# Altium schematic sheet karmanDB_amp.SchDoc
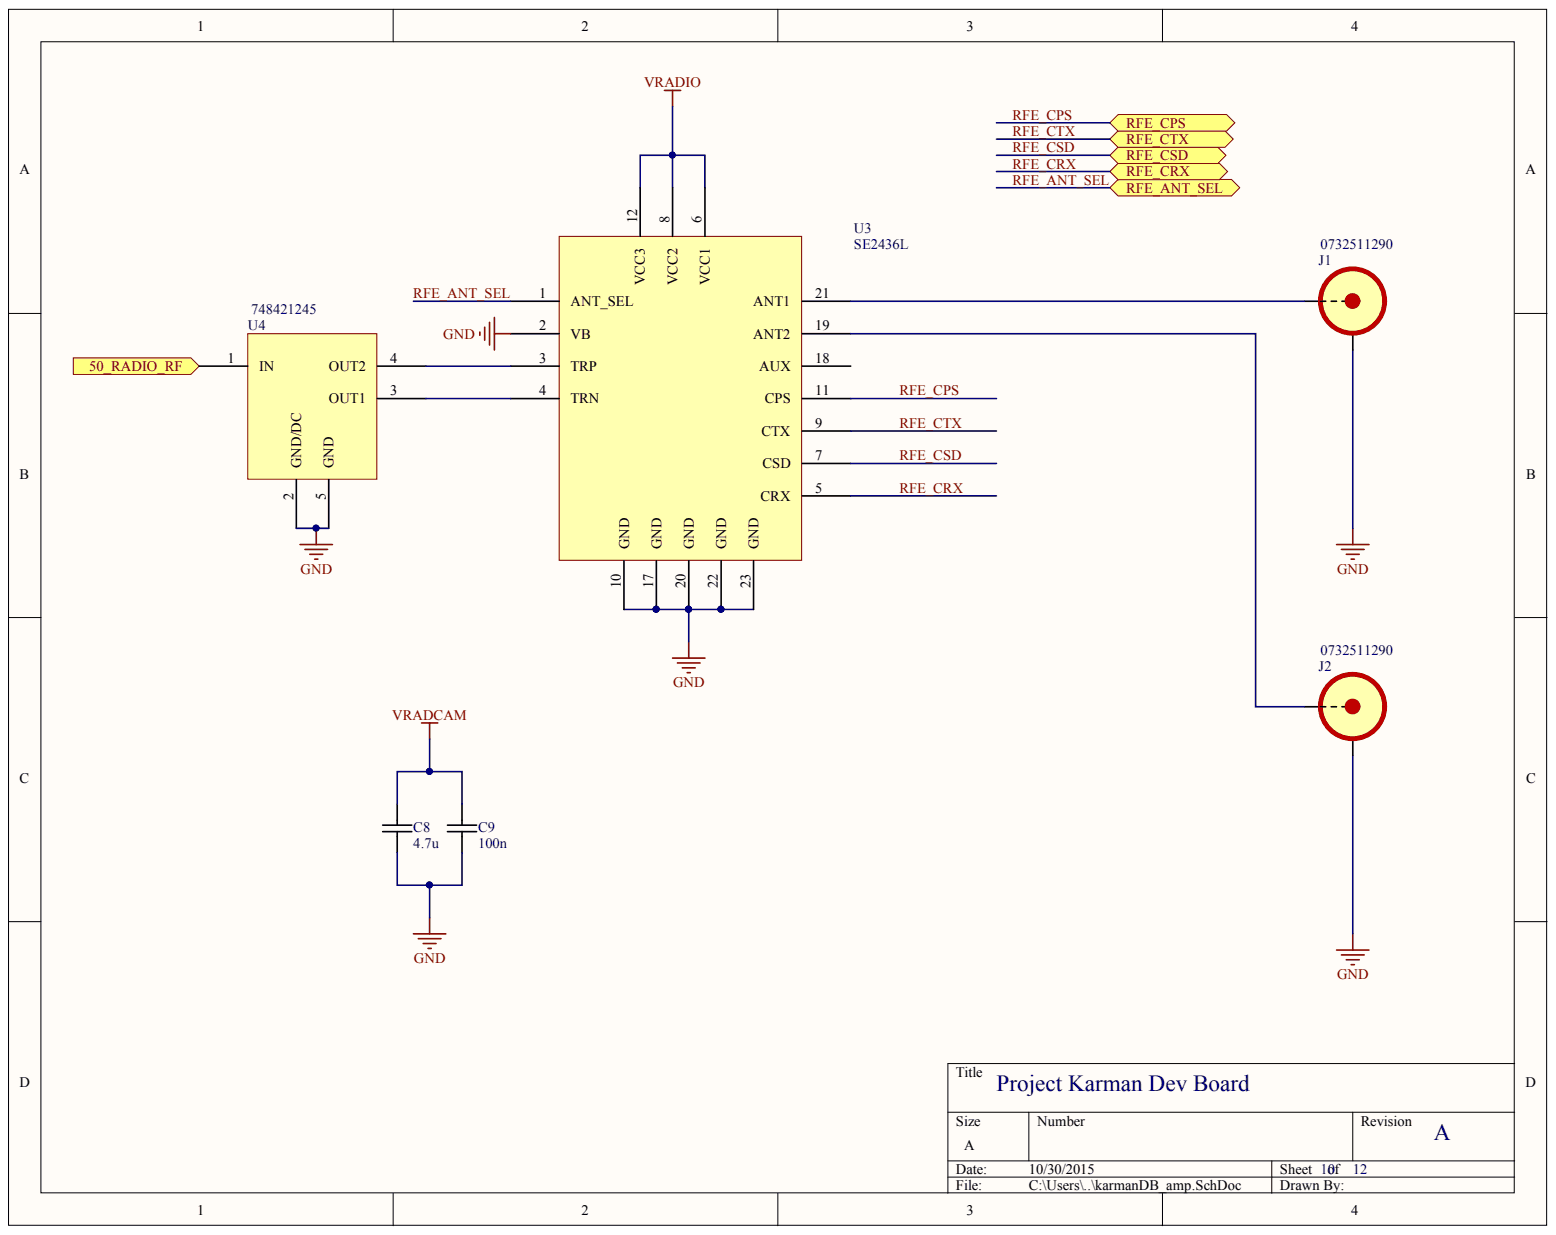
[diagram p0: karmanDB_amp.SchDoc]

## Parts
C8  4.7u  [MURATA_GRM_0603]
C9  100n  [MURATA_GRM_0603]
J1  0732511290
J2  0732511290
U3  SE2436L
U4  748421245

## Ports
50_RADIO_RF, RFE_ANT_SEL, RFE_CPS, RFE_CRX, RFE_CSD, RFE_CTX

## Nets
GND: C8.2 C9.2 J1.SHIELD J2.SHIELD U3.2(VB) U3.10(GND) U3.17(GND) U3.20(GND) U3.22(GND) U3.23(GND) U4.2(GND/DC) U4.5(GND)
VRADCAM: C8.1 C9.1
VRADIO: U3.6(VCC1) U3.8(VCC2) U3.12(VCC3)
50_RADIO_RF: U4.1(IN) ; port 50_RADIO_RF
RFE_ANT_SEL: U3.1(ANT_SEL) ; port RFE_ANT_SEL
RFE_CPS: U3.11(CPS) ; port RFE_CPS
RFE_CRX: U3.5(CRX) ; port RFE_CRX
RFE_CSD: U3.7(CSD) ; port RFE_CSD
RFE_CTX: U3.9(CTX) ; port RFE_CTX
NetJ1_PIN: J1.PIN U3.21(ANT1)
NetJ2_PIN: J2.PIN U3.19(ANT2)
NetU3_3: U3.3(TRP) U4.4(OUT2)
NetU3_4: U3.4(TRN) U4.3(OUT1)

Not connected: U3 18(AUX)

## Library data of the parts
C8 = CAP_MURATA_GRM188R61C475MAAJD: CAP CER 4.7UF 16V 20% X5R 0603; Manufacturer: Murata Electronics North America; Capacitance: 4.7µF; Applications: General Purpose; Category: Capacitors; Component Kind: Standard; ComponentLink1Description: http://www.murata.com/~/media/webrenewal/support/library/catalog/products/capacitor/mlcc/c02e.ashx; ComponentLink1URL: http://www.murata.com/~/media/webrenewal/support/library/catalog/products/capacitor/mlcc/c02e.ashx; Features: -; Height - Seated (Max): -; Lead Spacing: -; Lead Style: -; Library Name: project_symbols.SchLib; Manufacturer Part Number: GRM188R61C475MAAJD; Mounting Type: Surface Mount, MLCC; Operating Temperature: -55°C ~ 85°C; Package / Case: 0603 (1608 Metric); Packaging: Cut Tape (CT); Pricing: 1=0.3, 10=0.251, 25=0.1728, 50=0.1414, 100=0.1256, 250=0.0942, 500=0.08322, 1000=0.07379 (USD); Ratings: -; RoHS: RoHS Compliant; Size / Dimension: 0.063" L x 0.031" W (1.60mm x 0.80mm); Stock 1: 3465; Supplier 1: Digi-Key; Supplier Part Number 1: 490-10482-1-ND; Temperature Coefficient: X5R; Thickness (Max): 0.037" (0.95mm); Tolerance: ±20%; Voltage - Rated: 16V
C9 = CAP_MURATA_GRM188R71C104KA01D: CAP CER 0.1UF 16V 10% X7R 0603; Manufacturer: Murata Electronics North America; Capacitance: 0.1µF; Applications: General Purpose; Category: Capacitors; Component Kind: Standard; ComponentLink1Description: http://www.murata.com/~/media/webrenewal/support/library/catalog/products/capacitor/mlcc/c02e.ashx; ComponentLink1URL: http://www.murata.com/~/media/webrenewal/support/library/catalog/products/capacitor/mlcc/c02e.ashx; Features: -; Height - Seated (Max): -; Lead Spacing: -; Lead Style: -; Library Name: project_symbols.SchLib; Manufacturer Part Number: GRM188R71C104KA01D; Mounting Type: Surface Mount, MLCC; Operating Temperature: -55°C ~ 125°C; Package / Case: 0603 (1608 Metric); Packaging: Cut Tape (CT); Pricing: 1=0.1, 10=0.019, 50=0.0102, 100=0.0086, 250=0.00704, 500=0.006, 1000=0.00468 (USD); Ratings: -; RoHS: RoHS Compliant; Size / Dimension: 0.063" L x 0.031" W (1.60mm x 0.80mm); Stock 1: 2486193; Supplier 1: Digi-Key; Supplier Part Number 1: 490-1532-1-ND; Temperature Coefficient: X7R; Thickness (Max): 0.035" (0.90mm); Tolerance: ±10%; Voltage - Rated: 16V
J1 J2 = 0732511290: CONN SMA JACK EDGE MNT 50 OHM; Manufacturer: Molex, LLC; Body Finish: Gold; Body Material: Brass; Center Contact Material: Beryllium Copper; Connector Type: Jack, Female Socket; Contact Termination: Solder; Dielectric Material: Polytetrafluoroethylene (PTFE); Frequency - Max: 18GHz; Impedance: 50 Ohm; Voltage Rating: 500V; Cable Group: -; Category: Connectors, Interconnects; Center Contact Plating: Gold; Component Kind: Standard; ComponentLink1Description: http://www.molex.com/pdm_docs/sd/732511290_sd.pdf; ComponentLink1URL: http://www.molex.com/pdm_docs/sd/732511290_sd.pdf; Connector Style: SMA; Fastening Type: Threaded; Features: -; Housing Color: Gold; Ingress Protection: -; Manufacturer Part Number: 0732511290; Mounting Type: Board Edge, End Launch; Panel Mount, Bulkhead; Operating Temperature: -65°C ~ 165°C; Packaging: Tray; Pricing 1: 1=6.6, 10=6.327, 25=5.7772, 50=5.502, 100=5.2269, 250=4.67672, 500=4.4016, 1000=3.8514, 2500=3.65883 (USD); RoHS: RoHS Compliant; Shield Termination: Solder; Stock 1: 1325; Supplier 1: Digi-Key; Supplier Part Number 1: WM9461-ND; Library Name: karman_pcb.SCHLIB
U3 = SE2436L: ZIGBEE FRONT END MODULE; Manufacturer: Skyworks Solutions Inc.; Category: RF/IF and RFID; Component Kind: Standard; ComponentLink1Description: http://www.skyworksinc.com/uploads/documents/SE2436L_202413C.pdf; ComponentLink1URL: http://www.skyworksinc.com/uploads/documents/SE2436L_202413C.pdf; Manufacturer Part Number: SE2436L; Pricing 1: 3000=1.5 (USD); RoHS: RoHS Compliant; Stock 1: 0; Supplier 1: Digi-Key; Supplier Part Number 1: SE2436L-ND; Library Name: karman_pcb.SCHLIB
U4 = 748421245: BALUN EMBER351 & 357 0805 SMD; Manufacturer: Wurth Electronics Inc; Frequency Range: 2.4GHz ~ 2.5GHz; Impedance - Unbalanced/Balanced: 50 / 100 Ohm; Insertion Loss (Max): 1.0dB; Phase Difference: 180° ±10; Category: RF/IF and RFID; Component Kind: Standard; ComponentLink1Description: http://katalog.we-online.de/pbs/datasheet/748421245.pdf; ComponentLink1URL: http://katalog.we-online.de/pbs/datasheet/748421245.pdf; Manufacturer Part Number: 748421245; Mounting Type: Surface Mount; Package / Case: 0805 (2012 Metric); Packaging: Cut Tape (CT); Pricing 1: 1=2.63, 50=2.3626, 100=2.1875, 500=2.0125, 1000=1.925, 2000=1.8375 (USD); Return Loss (Min): -; RoHS: RoHS Compliant; Stock 1: 20266; Supplier 1: Digi-Key; Supplier Part Number 1: 732-2230-1-ND; Library Name: karman_pcb.SCHLIB
